# Altium schematic sheet Main.SchDoc(Main)
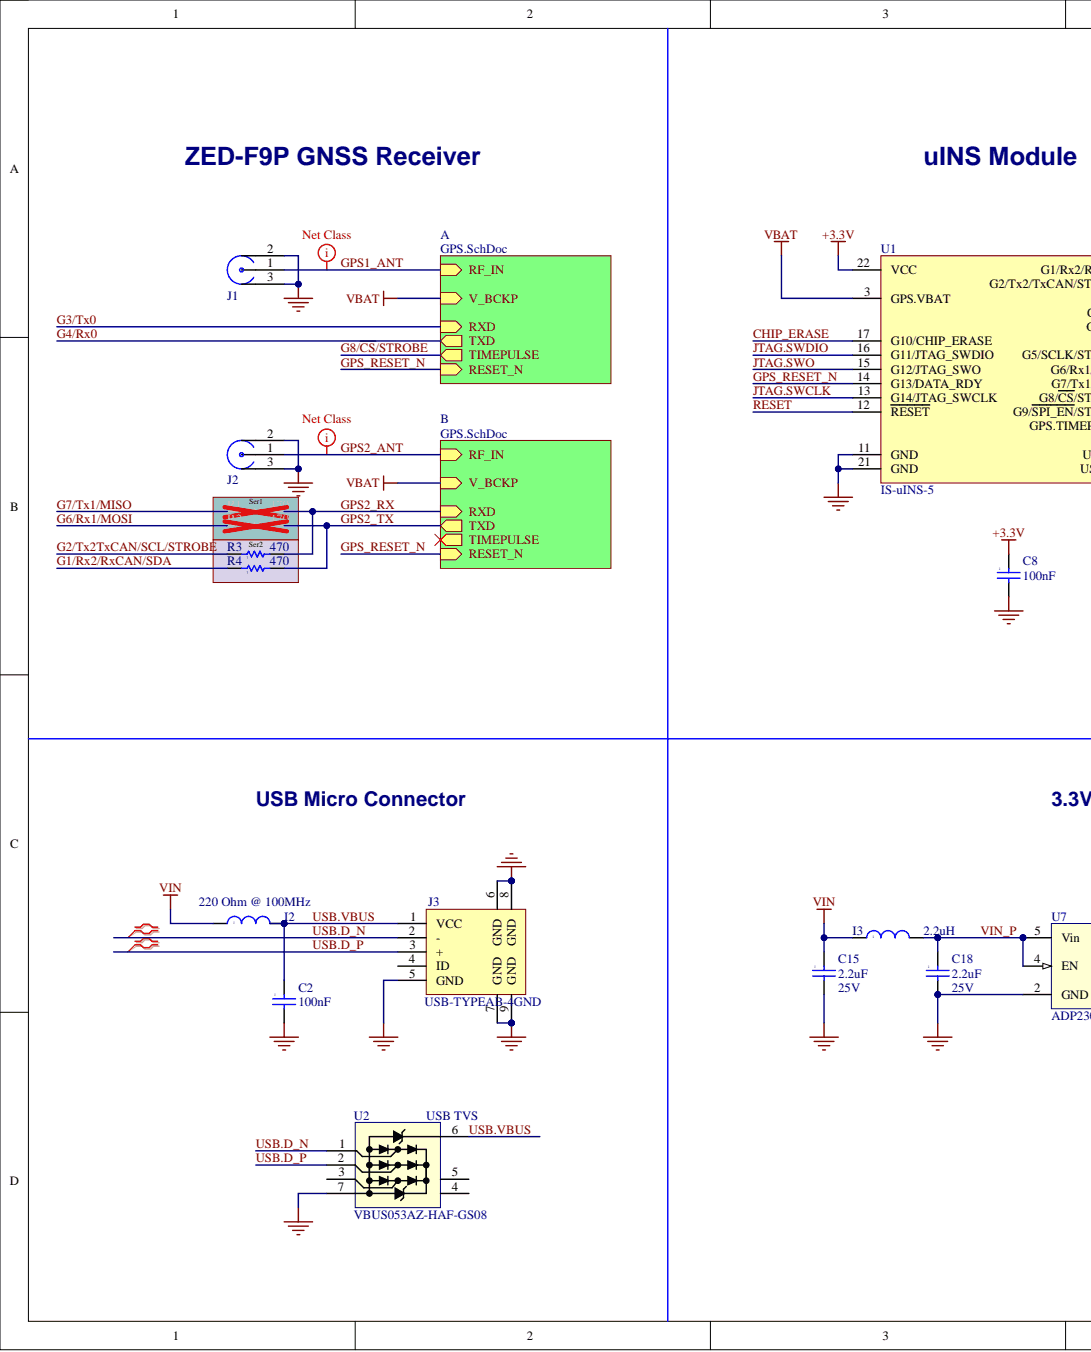
[diagram p0: Main.SchDoc, left]
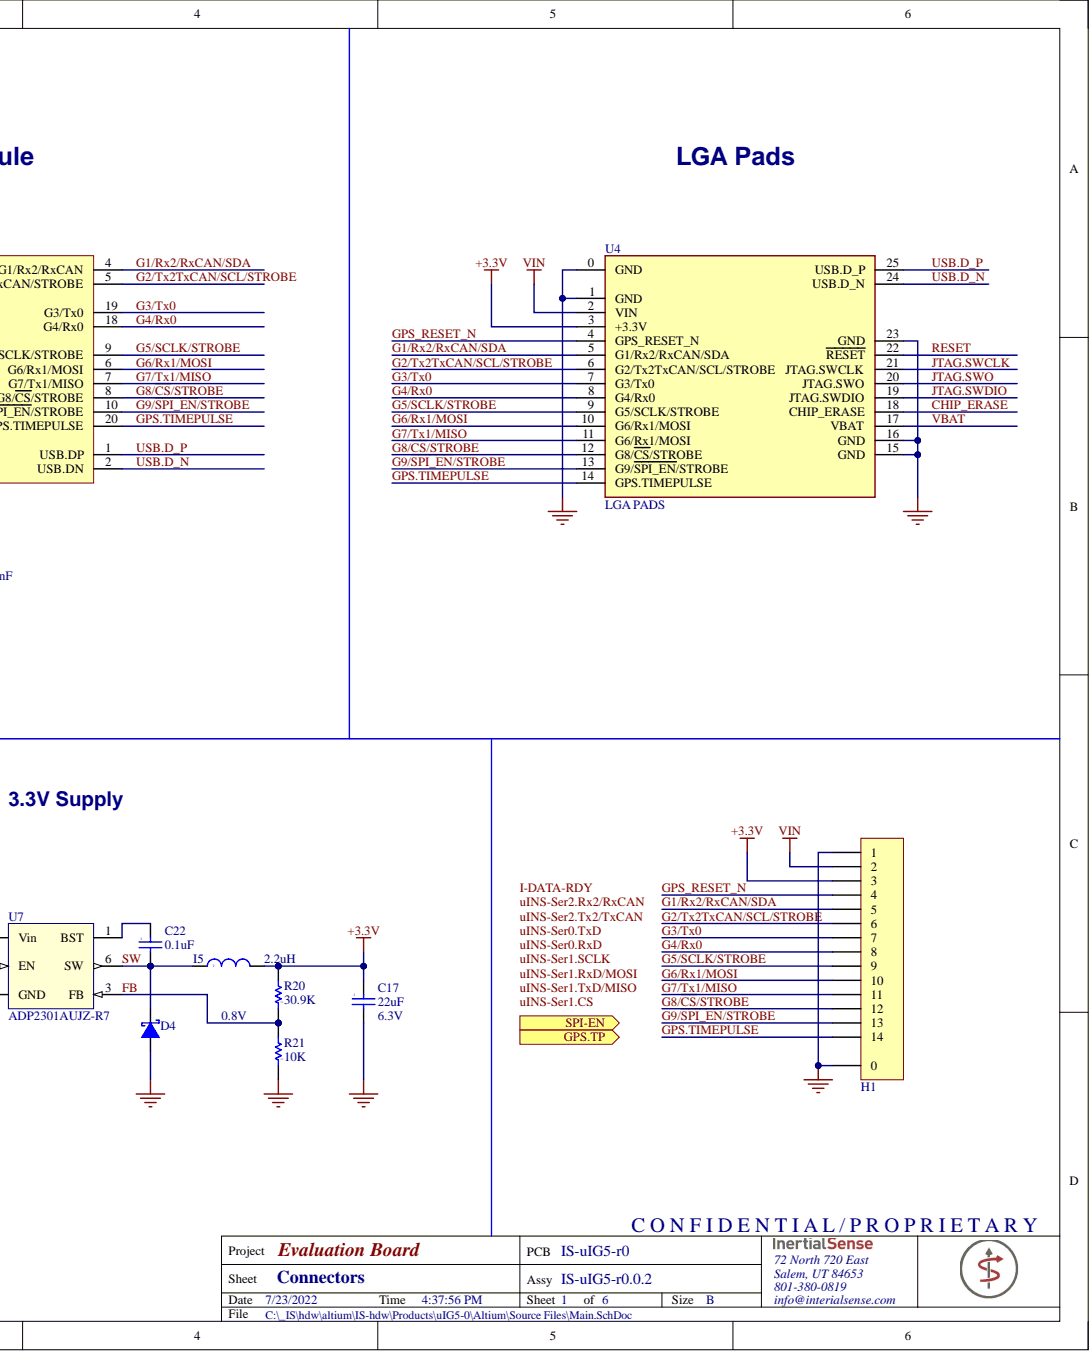
[diagram p1: Main.SchDoc, right]

## Parts
C2  value 100nF  [0402]  (hidden comment: Cap)
C8  value 100nF  [0402]  (hidden comment: Cap)
C15  value 2.2uF  Capacitance: 2.2uF  Voltage Rating: 25V  [0603]  (hidden comment: Cap)
C17  value 22uF  Capacitance: 22uF  Voltage Rating: 6.3V  [0603]  (hidden comment: Cap)
C18  value 2.2uF  Capacitance: 2.2uF  Voltage Rating: 25V  [0603]  (hidden comment: Cap)
C22  value 0.1uF  Capacitance: 0.1uF  [0402]  (hidden comment: Cap)
D4  Diode - Schottky  [D0603B]  (value not drawn)
H1  Hdr - 14pin - mount  [SM14B-GHS-TB(LF)(SN)]  (value not drawn)
I2  value 220 Ohm @ 100MHz  Impedance @ Frequency: 220 Ohm @ 100MHz  [I0603]  (hidden comment: Inductor)
I3  value 2.2uH  [0806]  (hidden comment: Inductor)
I5  value 2.2uH  [0806]  (hidden comment: Inductor)
J1  RF - GND x 2  [RF UMC SMD]  (value not drawn)
J2  RF - GND x 2  [RF UMC SMD]  (value not drawn)
J3  USB-TYPEAB-4GND  [USB Micro AB R/A THR]
R1  value 470  [R0402]  (hidden comment: Res)
R2  value 470  [R0402]  (hidden comment: Res)
R3  value 470  [R0402]  (hidden comment: Res)
R4  value 470  [R0402]  (hidden comment: Res)
R20  value 30.9K  Resistance (Ohms): 30.9K  [R0402]  (hidden comment: Res)
R21  value 10K  Resistance (Ohms): 10K  [R0402]  (hidden comment: Res)
U1  uINS  Manufacturer Part Number: IS-uINS-5  [IS-uINS-5.0]
U2  VBUS053  Manufacturer Part Number: VBUS053AZ-HAF-GS08  [QFN50P160X160X60-6N]
U4  LGA PADS  [uIG5p1_LGA]
U7  ADP2301  Manufacturer Part Number: ADP2301AUJZ-R7  [MO-178-AB]

## Ports
GPS.TP, SPI-EN

## Nets
+3.3V: C8.1 C17.1 H1.3 I5.2 R20.2 U1.22(VCC) U4.3(+3.3V)
GND: C2.2 C8.2 C15.2 C17.2 C18.2 D4.A H1.0 H1.1 J1.2 J1.3 J2.2 J2.3 J3.5(GND) J3.6(GND) J3.7(GND) J3.8(GND) J3.9(GND) R21.1 U1.11(GND) U1.21(GND) U2.7 U4.0(GND) U4.1(GND) U4.15(GND) U4.16(GND) U4.23(GND) U7.2(GND)
VIN: C15.1 H1.2 I2.1 I3.1 U4.2(VIN)
~{RESET}: U1.12(RESET) U4.22(RESET)
CHIP_ERASE: U1.17(G10/CHIP_ERASE) U4.18(CHIP_ERASE)
FB: R20.1 R21.2 U7.3(FB)
G1/Rx2/RxCAN/SDA: H1.5 R4.1 U1.4(G1/Rx2/RxCAN) U4.5(G1/Rx2/RxCAN/SDA)
G2/Tx2TxCAN/SCL/STROBE: H1.6 R3.1 U1.5(G2/Tx2/TxCAN/STROBE) U4.6(G2/Tx2TxCAN/SCL/STROBE)
G3/Tx0: H1.7 U1.19(G3/Tx0) U4.7(G3/Tx0)
G4/Rx0: H1.8 U1.18(G4/Rx0) U4.8(G4/Rx0)
G5/SCLK/STROBE: H1.9 U1.9(G5/SCLK/STROBE) U4.9(G5/SCLK/STROBE)
G6/Rx1/MOSI: H1.10 R2.1 U1.6(G6/Rx1/MOSI) U4.10(G6/Rx1/MOSI)
G7/Tx1/MISO: H1.11 R1.1 U1.7(G7/Tx1/MISO) U4.11(G6/Rx1/MOSI)
G8/~{CS}/STROBE: H1.12 U1.8(G8/CS/STROBE) U4.12(G8/CS/STROBE)
G9/~{SPI_EN}/STROBE: H1.13 U1.10(G9/SPI_EN/STROBE) U4.13(G9/SPI_EN/STROBE)
GPS1_ANT: J1.1
GPS2_ANT: J2.1
GPS2_RX: R1.2 R3.2
GPS2_TX: R2.2 R4.2
GPS.TIMEPULSE: H1.14 U1.20(GPS.TIMEPULSE) U4.14(GPS.TIMEPULSE)
GPS_RESET_N: H1.4 U1.14(G13/DATA_RDY) U4.4(GPS_RESET_N)
JTAG.SWCLK: U1.13(G14/JTAG_SWCLK) U4.21(JTAG.SWCLK)
JTAG.SWDIO: U1.16(G11/JTAG_SWDIO) U4.19(JTAG.SWDIO)
JTAG.SWO: U1.15(G12/JTAG_SWO) U4.20(JTAG.SWO)
SW: C22.2 D4.C I5.1 U7.6(SW)
USB.D_N: J3.2(-) U1.2(USB.DN) U2.1 U4.24(USB.D_N)
USB.D_P: J3.3(+) U1.1(USB.DP) U2.2 U4.25(USB.D_P)
USB.VBUS: C2.1 I2.2 J3.1(VCC) U2.6
VBAT: U1.3(GPS.VBAT) U4.17(VBAT)
VIN_P: C18.1 I3.2 U7.4(EN) U7.5(Vin)
NetC22_1: C22.1 U7.1(BST)
NetJ3_4: J3.4(ID)
NetU2_3: U2.3
NetU2_4: U2.4
NetU2_5: U2.5
I-DATA-RDY:
N00001:
N00002: ; port SPI-EN
N00003: ; port GPS.TP
uINS-Ser0.RxD:
uINS-Ser0.TxD:
uINS-Ser1.CS:
uINS-Ser1.RxD/MOSI:
uINS-Ser1.SCLK:
uINS-Ser1.TxD/MISO:
uINS-Ser2.Rx2/RxCAN:
uINS-Ser2.Tx2/TxCAN:

## Library data of the parts
C2 C8 = Cap: CAP CER .10UF 50V X7R 10% 0402; Manufacturer: TDK Corporation; Manufacturer Part Number: C1005X7R1H104K; Capacitance: 0.1µF; Applications: General Purpose; Category: Capacitors; Component Kind: Standard; ComponentLink1Description: http://www.tdk.co.jp/tefe02/e412_c.pdf; ComponentLink1URL: http://www.tdk.co.jp/tefe02/e412_c.pdf; Features: -; Height: -; LatestRevisionDate: 17-Jul-2002; LatestRevisionNote: Re-released for DXP Platform.; Lead Spacing: -; Lead Style: -; Library Name: General.SchLib; Mounting Type: Surface Mount, MLCC; Operating Temperature: -55°C ~ 125°C; Package / Case: 0402 (1005 Metric); Packaging: Cut Tape (CT); Pricing 1: 1=0.3, 10=0.2, 100=0.08, 250=0.05752, 500=0.041, 1000=0.03 (USD); Published: 8-Jun-2000; Ratings: -; RoHS: RoHS Compliant; Signal Integrity: Cap; Simulation: CAP; Size / Dimension: 0.039" L x 0.020" W (1.00mm x 0.50mm); Stock 1: 174080; Supplier 1: Digi-Key; Supplier Part Number 1: 445-5932-1-ND; Temperature Coefficient: X7R; Thickness: 0.022" (0.55mm); Tolerance: ±10%; Voltage - Rated: 50V; Publisher: Altium Limited
C15 C18 = Cap: CAP CER 2.2UF 25V X6S 0603; Manufacturer: Murata; Manufacturer Part Number: GRT188C81E225KE13D; Tolerance: 10%; Case Code (Imperial): 0603; Case Code (Metric): 1608; Case/Package: 0603; Category: Capacitors; Component Kind: Standard; ComponentLink1Description: http://www.farnell.com/datasheets/2165949.pdf; ComponentLink1URL: http://www.farnell.com/datasheets/2165949.pdf; ComponentLink2Description: http://search.murata.co.jp/Ceramy/image/img/A01X/G101/ENG/GRT188C81E225KE13-01.pdf; ComponentLink2URL: http://search.murata.co.jp/Ceramy/image/img/A01X/G101/ENG/GRT188C81E225KE13-01.pdf; Dielectric: X6S; LatestRevisionDate: 17-Jul-2002; LatestRevisionNote: Re-released for DXP Platform.; Length: 0.063inch; Library Name: General.SchLib; Max Operating Temperature: 105°C; Min Operating Temperature: -55°C; Mount: Surface Mount; Packaging: Tape and Reel; Pricing 1: 1=0.35, 10=0.239, 100=0.1355, 500=0.09564, 1000=0.08369 (USD); Published: 8-Jun-2000; Signal Integrity: Cap; Simulation: CAP; Stock 1: 16854; Supplier 1: Digi-Key; Supplier Part Number 1: 490-12293-6-ND; Thickness: 0.035inch; Voltage Rating (DC): 25V; Width: 0.031inch; Publisher: Altium Limited
C17 = Cap: CAP CER 22UF 6.3V X6S 0603; Manufacturer: Murata; Manufacturer Part Number: GRM188C80J226ME15D; Tolerance: 20%; Case Code (Imperial): 0603; Case Code (Metric): 1608; Case/Package: 0603; Category: Capacitors; Component Kind: Standard; ComponentLink1Description: http://www.mouser.com/ds/2/281/c02e-2905.pdf; ComponentLink1URL: http://www.mouser.com/ds/2/281/c02e-2905.pdf; ComponentLink2Description: http://search.murata.co.jp/Ceramy/image/img/A01X/partnumbering_e_01.pdf; ComponentLink2URL: http://search.murata.co.jp/Ceramy/image/img/A01X/partnumbering_e_01.pdf; Dielectric: X6S; Height: 0.8mm; LatestRevisionDate: 17-Jul-2002; LatestRevisionNote: Re-released for DXP Platform.; Lead Free: Lead Free; Length: 0.063inch; Library Name: General.SchLib; Max Operating Temperature: 105°C; Min Operating Temperature: -55°C; Mount: Surface Mount; Package Quantity: 4000; Packaging: Tape and Reel; Pricing 1: 1=0.25, 10=0.168, 100=0.0955, 500=0.0674, 1000=0.05897 (USD); Published: 8-Jun-2000; Signal Integrity: Cap; Simulation: CAP; Stock 1: 0; Supplier 1: Digi-Key; Supplier Part Number 1: 490-10471-6-ND; Termination: SMD/SMT; Thickness: 0.039inch; Voltage Rating (DC): 6.3V; Weight: 0.000222oz; Width: 0.8mm; Publisher: Altium Limited
C22 = Cap: CAP CER 0.1UF 50V X7R 0402; Manufacturer: TDK; Manufacturer Part Number: CGA2B3X7R1H104K050BB; Tolerance: 10%; Case Code (Imperial): 0402; Case Code (Metric): 1005; Case/Package: 0402; Category: Capacitors; Component Kind: Standard; ComponentLink1Description: https://datasheet.ciiva.com/16498/mlccspec-automotive-general-midvoltage-en-16498528.pdf?src-supplier=Future+Electronics; ComponentLink1URL: https://datasheet.ciiva.com/16498/mlccspec-automotive-general-midvoltage-en-16498528.pdf?src-supplier=Future+Electronics; ComponentLink2Description: https://datasheet.ciiva.com/24216/cga2b3x7r1h104k050bb-266281-24216070.pdf?src-supplier=Mouser; ComponentLink2URL: https://datasheet.ciiva.com/24216/cga2b3x7r1h104k050bb-266281-24216070.pdf?src-supplier=Mouser; ComponentLink3Description: https://datasheet.ciiva.com/7975/1788388-7975306.pdf?src-supplier=Element14; ComponentLink3URL: https://datasheet.ciiva.com/7975/1788388-7975306.pdf?src-supplier=Element14; ComponentLink4Description: https://datasheet.ciiva.com/22703/1807242-22703013.pdf?src-supplier=Element14; ComponentLink4URL: https://datasheet.ciiva.com/22703/1807242-22703013.pdf?src-supplier=Element14; ComponentLink5Description: https://datasheet.ciiva.com/22725/1735392-22725627.pdf?src-supplier=Element14; ComponentLink5URL: https://datasheet.ciiva.com/22725/1735392-22725627.pdf?src-supplier=Element14; Composition: Ceramic; Depth: 0.5mm; Dielectric: X7R; Height: 0.5mm; LatestRevisionDate: 17-Jul-2002; LatestRevisionNote: Re-released for DXP Platform.; Lead Free: Lead Free; Length: 1mm; Library Name: General.SchLib; Max Operating Temperature: 125°C; Min Operating Temperature: -55°C; Mount: Surface Mount; Package Quantity: 1; Packaging: Tape and Reel; Pricing 1: 1=0.16000, 10=0.11700, 100=0.05500, 500=0.03858, 1000=0.03194, 2500=0.02973, 5000=0.02720 (USD); Published: 8-Jun-2000; Ratings: AEC-Q200; REACH SVHC: No SVHC; RoHS: Yes; Signal Integrity: Cap; Simulation: CAP; Stock 1: 12402793; Supplier 1: Digi-Key; Supplier Part Number 1: 445-6899-1-ND; Termination: SMD/SMT; Thickness: 0.022inch; Voltage: 50V; Voltage Rating: 50V; Voltage Rating (DC): 50V; Weight: 2.3E-05oz; Width: 0.02inch; Publisher: Altium Limited
D4 = Diode - Schottky: DIODE SCHOTTKY 30V 2A 0603; Manufacturer: Vishay; Manufacturer Part Number: VSKY20301608-G4-08; Component Kind: Standard; ComponentLink1Description: http://www.mouser.com/ds/2/427/vsky20301608-777242.pdf; ComponentLink1URL: http://www.mouser.com/ds/2/427/vsky20301608-777242.pdf; Library Name: General.SchLib; Pricing 1: 1=0.38, 10=0.305, 25=0.2792, 100=0.2076, 250=0.1882, 500=0.15574, 1000=0.1168, 2500=0.10707 (USD); Simulation: SKYDIODE; Stock 1: 13064; Supplier 1: Digi-Key; Supplier Part Number 1: VSKY20301608-G4-08GICT-ND
H1 = Hdr - 14pin - mount: Conn Shrouded Header HDR 14 POS 1.25mm Solder ST Top Entry SMD T/R; Manufacturer: JST; Category: Connectors; Component Kind: Standard; Library Name: Connectors.SchLib; Manufacturer Part Number: SM14B-GHS-TB(LF)(SN); Pricing 1: 2500=0.35044, 5000=0.33876, 12500=0.31072, 25000=0.30371 (USD); RoHS: No; Supplier 1: Digi-Key; Supplier Part Number 1: 455-1576-2-ND
I2 = Inductor: FERRITE CHIP 220 OHM 2500MA 0603; Manufacturer: Murata Electronics North America; Manufacturer Part Number: BLM18SG221TN1D; Current Rating: 2.5A; DC Resistance (DCR): 40 mOhm Max; Filter Type: Differential Mode - Single; Category: Filters; Component Kind: Standard; ComponentLink1Description: http://search.murata.co.jp/Ceramy/image/img/PDF/ENG/L0110S0100BLM18S.pdf; ComponentLink1URL: http://search.murata.co.jp/Ceramy/image/img/PDF/ENG/L0110S0100BLM18S.pdf; Height (Max): 0.037" (0.95mm); Library Name: General.SchLib; Mounting Type: Surface Mount; Package / Case: 0603 (1608 Metric); Packaging: Cut Tape (CT); Pricing 1: 1=0.14, 10=0.116, 50=0.075, 100=0.0646, 250=0.05172, 500=0.04654, 1000=0.03878, 5000=0.03619 (USD); RoHS: RoHS Compliant; Size / Dimension: 0.063" L x 0.031" W (1.60mm x 0.80mm); Stock 1: 146728; Supplier 1: Digi-Key; Supplier Part Number 1: 490-5225-1-ND
I3 I5 = Inductor: FIXED IND 2.2UH 1.2A 110 MOHM; Manufacturer: TDK; Manufacturer Part Number: MLP2016H2R2MT0S1; Core Material: Ferrite; DC Resistance (DCR): 137.5mR; Shielding: Unshielded; Test Frequency: 2MHz; Tolerance: 20%; Case Code (Imperial): 0806; Case Code (Metric): 2016; Case/Package: 0806; Component Kind: Standard; ComponentLink1Description: https://datasheet.ciiva.com/29697/inductor-commercial-power-mlp-en-29697725.pdf; ComponentLink1URL: https://datasheet.ciiva.com/29697/inductor-commercial-power-mlp-en-29697725.pdf; ComponentLink2Description: https://datasheet.ciiva.com/29697/inductor-commercial-power-mlp-en-29697726.pdf; ComponentLink2URL: https://datasheet.ciiva.com/29697/inductor-commercial-power-mlp-en-29697726.pdf; Current Rating: 1.2A; Height - Seated (Max): 0.039inch; Inductance: 2.2uh; Lead Free: Lead Free; Length: 0.079inch; Library Name: General.SchLib; Max Operating Temperature: 125°C; Min Operating Temperature: -40°C; Mount: Surface Mount; Package Quantity: 3000; Packaging: Tape and Reel; Pricing 1: 1=0.38, 10=0.335, 100=0.2302, 500=0.19674, 1000=0.1507 (USD); Stock 1: 14048; Supplier 1: Digi-Key; Supplier Part Number 1: 445-15724-6-ND; Width: 0.063inch
J1 J2 = RF - GND x 2: CONN UMC RCPT STR 50 OHM SMD; Manufacturer: Emerson Network Power Connectivity Johnson; Manufacturer Part Number: 128-0711-201; Body Finish: Gold; Connector Style: Ultra Miniature Coaxial; Connector Type: Receptacle, Male Pins; Contact Termination: Solder; Frequency - Max: 6GHz; Impedance: 50 Ohm; Voltage Rating: 200V; Body Material: -; Cable Group: -; Category: Connectors, Interconnects; Center Contact Material: -; Center Contact Plating: Gold; Component Kind: Standard; ComponentLink1Description: http://media.digikey.com/pdf/Data%20Sheets/Emerson%20PDFs/UMC%20Product%20Catalog.pdf; ComponentLink1URL: http://media.digikey.com/pdf/Data%20Sheets/Emerson%20PDFs/UMC%20Product%20Catalog.pdf; Dielectric Material: -; Fastening Type: Snap-On; Features: -; Housing Color: Gold; Ingress Protection: -; Library Name: Connectors.SchLib; Mounting Type: Surface Mount; Operating Temperature: -40°C ~ 90°C; Packaging: Cut Tape (CT); Pricing 1: 1=0.93, 10=0.832, 50=0.784, 100=0.672, 250=0.576, 500=0.544, 750=0.48, 1000=0.448 (USD); RoHS: RoHS Compliant; Shield Termination: Solder; Stock 1: 1514; Supplier 1: Digi-Key; Supplier Part Number 1: J983CT-ND
J3 = USB-TYPEAB-4GND: CONN USB AB 4POS; Manufacturer: Amphenol FCI; Manufacturer Part Number: 10118194-0001LF; Connector Type: USB,Receptacle; Current Rating: 1.8A; Gender: Receptacle; Insulation Resistance: 1GR; Max Voltage Rating (AC): 100V; Number of Contacts: 5; Number of Ports: 1; Pitch: 0.65mm; Colour: Black; Component Kind: Standard; ComponentLink1Description: https://datasheet.ciiva.com/851/10118194-851110.pdf; ComponentLink1URL: https://datasheet.ciiva.com/851/10118194-851110.pdf; ComponentLink2Description: https://datasheet.ciiva.com/851/10118194-851111.pdf; ComponentLink2URL: https://datasheet.ciiva.com/851/10118194-851111.pdf; ComponentLink3Description: https://datasheet.ciiva.com/4598/10118194ac-197217-4598807.pdf; ComponentLink3URL: https://datasheet.ciiva.com/4598/10118194ac-197217-4598807.pdf; ComponentLink4Description: https://datasheet.ciiva.com/29907/10118194-29907230.pdf; ComponentLink4URL: https://datasheet.ciiva.com/29907/10118194-29907230.pdf; ComponentLink5Description: https://datasheet.ciiva.com/26945/getdatasheetpartid-418619-26945695.pdf; ComponentLink5URL: https://datasheet.ciiva.com/26945/getdatasheetpartid-418619-26945695.pdf; Contact Material: Copper Alloy; Contact Plating: Gold; Depth: 5mm; Features: Shielded; Flammability Rating: UL94 V-0; Housing Material: Polymer; Lead Free: Lead Free; Length: 8.05mm; Library Name: Connectors.SchLib; Max Operating Temperature: 85°C; Min Operating Temperature: -55°C; Mount: Surface Mount,Horizontal; Number of Terminals: 5; Orientation: Right Angle; Package Quantity: 9600; Packaging: Tape and Reel; Pricing 1: 1=0.48, 10=0.447, 25=0.402, 50=0.3574, 100=0.3424, 250=0.31264, 500=0.29776 (USD); Radiation Hardening: No; Stock 1: 77572; Supplier 1: Digi-Key; Supplier Part Number 1: 609-4618-1-ND; Termination: Solder
R1-R4 = Res: RES 470 OHM 1/16W 1% 0402 SMD; Manufacturer: Vishay Dale; Manufacturer Part Number: CRCW0402470RFKED; Power (Watts): 0.063W, 1/16W; Temperature Coefficient: ±100ppm/°C; Category: Resistors; Component Kind: Standard; ComponentLink1Description: http://www.vishay.com/doc?20035; ComponentLink1URL: http://www.vishay.com/doc?20035; Composition: Thick Film; Features: Automotive AEC-Q200 Qualified; Height: 0.016" (0.40mm); Library Name: General.SchLib; Number of Terminations: 2; Package / Case: 0402 (1005 Metric); Packaging: Cut Tape (CT); Pricing 1: 10=0.083, 50=0.0444, 200=0.0255, 1000=0.0174 (USD); Resistance (Ohms): 470; RoHS: RoHS Compliant; Signal Integrity: Res; Simulation: RES; Size / Dimension: 0.039" L x 0.020" W (1.00mm x 0.50mm); Stock 1: 121698; Supplier 1: Digi-Key; Supplier Device Package: 0402 (1005 Metric); Supplier Part Number 1: 541-470LCT-ND; Tolerance: ±1%
R20 = Res: RES TF 30.9K OHM 1% 1/16W 0402; Manufacturer: Stackpole Electronics Inc; Manufacturer Part Number: RMCF0402FT30K9; Power (Watts): 0.063W, 1/16W; Temperature Coefficient: ±100ppm/°C; Category: Resistors; Component Kind: Standard; ComponentLink1Description: http://www.seielect.com/Catalog/SEI-RMCF.pdf; ComponentLink1URL: http://www.seielect.com/Catalog/SEI-RMCF.pdf; Composition: Thick Film; Features: -; Height: 0.016" (0.40mm); LatestRevisionDate: 2-Dec-2009; Library Name: General.SchLib; Number of Terminations: 2; Package / Case: 0402 (1005 Metric); Packaging: Cut Tape (CT); Pricing 1: 1=0.04, 10=0.025, 50=0.0138, 100=0.0105, 250=0.0078, 500=0.00576, 1000=0.00384 (USD); RoHS: RoHS Compliant; Signal Integrity: Res; Simulation: RES; Size / Dimension: 0.039" L x 0.020" W (1.00mm x 0.50mm); Stock 1: 6328; Supplier 1: Digi-Key; Supplier Part Number 1: RMCF0402FT30K9CT-ND; Tolerance: ±1%
R21 = Res: RES 10.0K OHM 1/16W 1% 0402 SMD; Manufacturer: Yageo; Manufacturer Part Number: RC0402FR-0710KL; Power (Watts): 0.063W, 1/16W; Temperature Coefficient: ±100ppm/°C; Category: Resistors; Component Kind: Standard; ComponentLink1Description: http://www.yageo.com/documents/recent/PYu-RC0402_51_RoHS_L_6.pdf; ComponentLink1URL: http://www.yageo.com/documents/recent/PYu-RC0402_51_RoHS_L_6.pdf; Composition: Thick Film; Features: -; Height: 0.014" (0.35mm); LatestRevisionDate: 2-Dec-2009; Library Name: General.SchLib; Number of Terminations: 2; Package / Case: 0402 (1005 Metric); Packaging: Cut Tape (CT); Pricing 1: 10=0.014, 50=0.0104, 100=0.0079, 250=0.00584, 500=0.00432, 1000=0.00288 (USD); RoHS: RoHS Compliant; Signal Integrity: Res; Simulation: RES; Size / Dimension: 0.039" L x 0.020" W (1.00mm x 0.50mm); Stock 1: 5597980; Supplier 1: Digi-Key; Supplier Part Number 1: 311-10.0KLRCT-ND; Tolerance: ±1%
U1 = IS-uINS-5.0: Inertial Sense uINS; Component Kind: Standard; Library Name: IS-uINS-5.SchLib; Manufacturer: Inertial Sense; Supplier 1: Inertial Sense; Supplier Part Number 1: IS-uINS-5
U2 = VBUS053: ESD SUPPRESS 5.5V 36W 3-Line USB; Manufacturer: Vishay Semiconductors; Breakdown Voltage: 6.5 V; Capacitance: 0.7 pF; Clamping Voltage: 18 V; Operating Voltage: 5.5 V (Min); Category: ESD Suppressors; Component Kind: Standard; ComponentLink1Description: http://www.vishay.com/docs/81845/vbus053a.pdf; ComponentLink1URL: http://www.vishay.com/docs/81845/vbus053a.pdf; Library Name: ICs.SchLib; Minimum Order Quantity: 1; Operating Temperature Range: - 40 C to + 125 C; Package / Case: LLP-75; Packaging: Reel; Pricing 1: 1=1.04, 10=0.918, 50=0.805, 100=0.689, 500=0.574, 1000=0.446, 3000=0.366, 9000=0.335 (AUD); Product Category: Transient Voltage Suppressors; Reeling: True; RoHS: RoHS Compliant; RoHS - Mouser: Y; Series: VBUS053AZ-HAF; Stock 1: 8176; Supplier 1: Mouser; Supplier Part Number 1: 78-VBUS053AZ-HAF
U4 = uIG5p0_LGA: Inertial Sense uIG5 GNSS INS Module; Component Kind: Standard; Library Name: Sensors.SchLib
U7 = ADP2301: IC BUCK ADJ 1.2A 6TSOT; Manufacturer: Analog Devices Inc; Frequency - Switching: 1.4MHz; Number of Outputs: 1; Output Type: Adjustable; PWM Type: Current Mode; Synchronous Rectifier: No; Voltage - Input: 3 V ~ 20 V; Voltage - Output: 0.8 V ~ 17 V; Category: Integrated Circuits (ICs); Component Kind: Standard; ComponentLink1Description: http://www.analog.com/static/imported-files/data_sheets/ADP2300_2301.pdf; ComponentLink1URL: http://www.analog.com/static/imported-files/data_sheets/ADP2300_2301.pdf; Current - Output: 1.2A; Library Name: ICs.SchLib; Mounting Type: Surface Mount; Operating Temperature: -40°C ~ 125°C; Package / Case: SOT-23-6 Thin, TSOT-23-6; Packaging: Cut Tape (CT); Pricing 1: 1=3.06, 25=2.4992, 100=2.244, 250=2.04, 500=1.785, 1000=1.53 (USD); RoHS: RoHS Compliant; Stock 1: 6699; Supplier 1: Digi-Key; Supplier Device Package: TSOT-6; Supplier Part Number 1: ADP2301AUJZ-R7CT-ND; Type: Step-Down (Buck)
